# Altium schematic sheet SOC Led.SchDoc
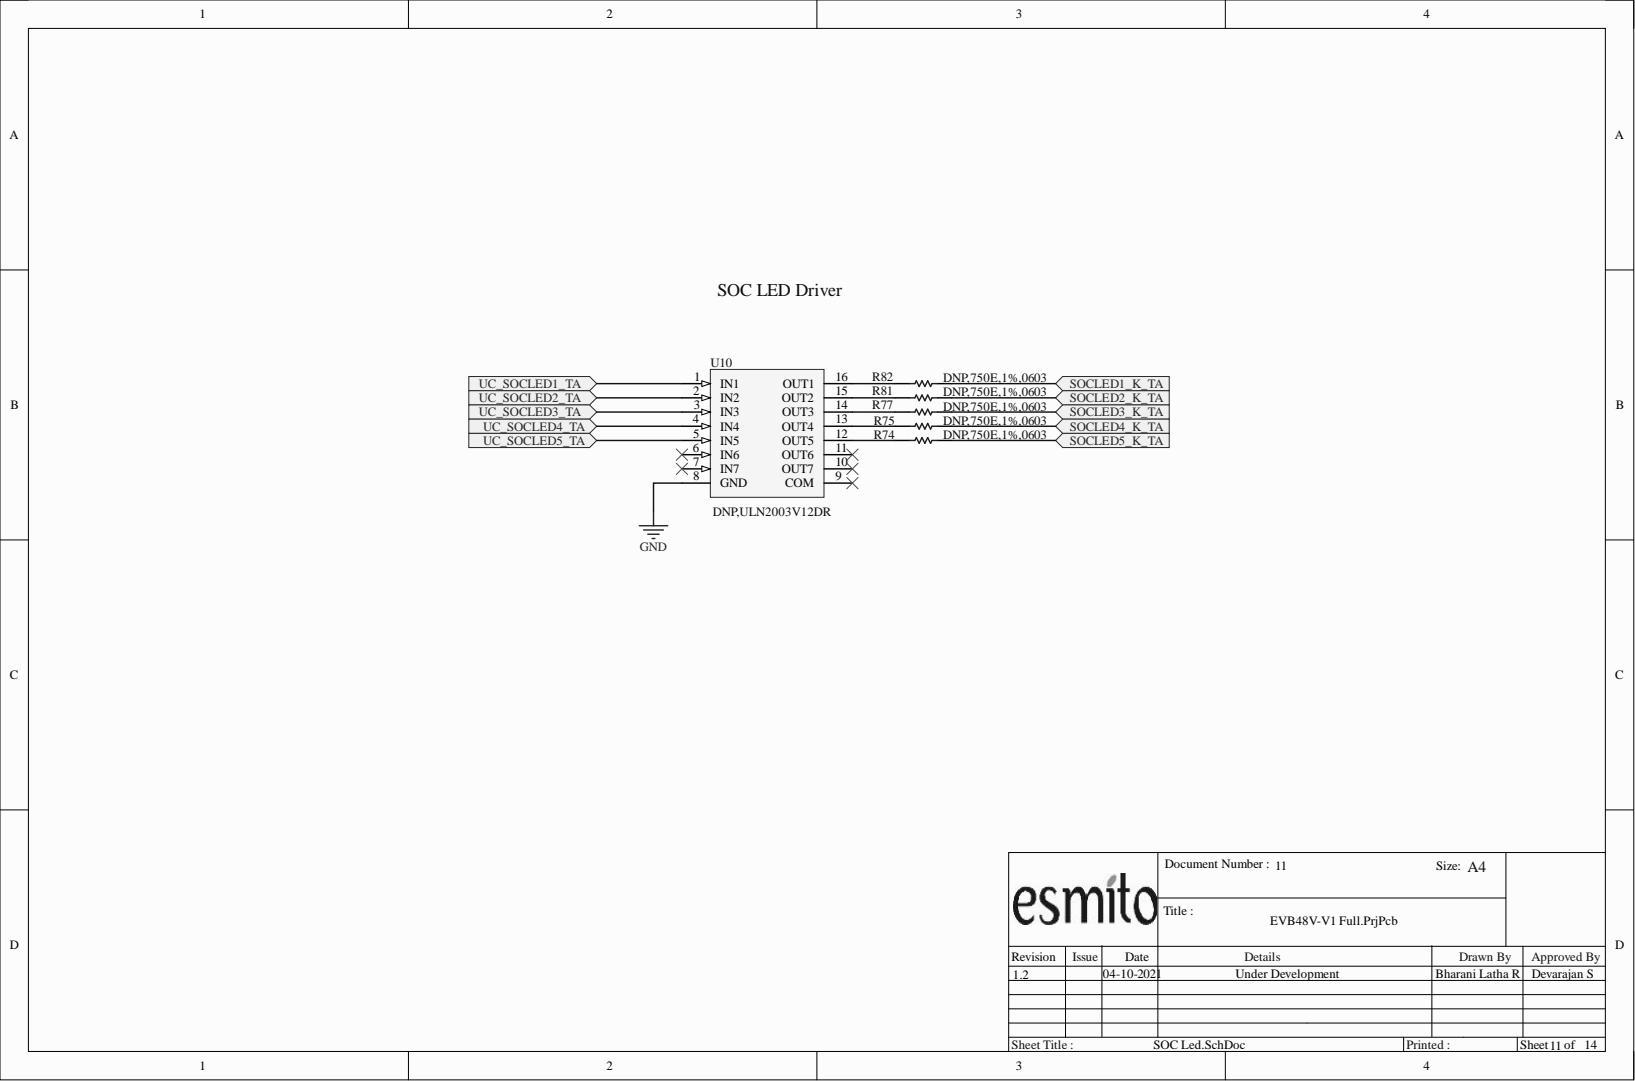
[diagram p0: SOC Led.SchDoc]

## Parts
R74  value DNP,750E,1%,0603  [0603_R_M]  (hidden comment: R_Semi)
R75  value DNP,750E,1%,0603  [0603_R_M]  (hidden comment: R_Semi)
R77  value DNP,750E,1%,0603  [0603_R_M]  (hidden comment: R_Semi)
R81  value DNP,750E,1%,0603  [0603_R_M]  (hidden comment: R_Semi)
R82  value DNP,750E,1%,0603  [0603_R_M]  (hidden comment: R_Semi)
U10  ULN2003  value DNP,ULN2003V12DR  [ULN2003V12S16-13]

## Ports
SOCLED1_K_TA, SOCLED2_K_TA, SOCLED3_K_TA, SOCLED4_K_TA, SOCLED5_K_TA, UC_SOCLED1_TA, UC_SOCLED2_TA, UC_SOCLED3_TA, UC_SOCLED4_TA, UC_SOCLED5_TA

## Nets
GND: U10.8(GND)
SOCLED1_K_TA: R82.2 ; port SOCLED1_K_TA
SOCLED2_K_TA: R81.2 ; port SOCLED2_K_TA
SOCLED3_K_TA: R77.2 ; port SOCLED3_K_TA
SOCLED4_K_TA: R75.2 ; port SOCLED4_K_TA
SOCLED5_K_TA: R74.2 ; port SOCLED5_K_TA
UC_SOCLED1_TA: U10.1(IN1) ; port UC_SOCLED1_TA
UC_SOCLED2_TA: U10.2(IN2) ; port UC_SOCLED2_TA
UC_SOCLED3_TA: U10.3(IN3) ; port UC_SOCLED3_TA
UC_SOCLED4_TA: U10.4(IN4) ; port UC_SOCLED4_TA
UC_SOCLED5_TA: U10.5(IN5) ; port UC_SOCLED5_TA
NetR74_1: R74.1 U10.12(OUT5)
NetR75_1: R75.1 U10.13(OUT4)
NetR77_1: R77.1 U10.14(OUT3)
NetR81_1: R81.1 U10.15(OUT2)
NetR82_1: R82.1 U10.16(OUT1)

Not connected: U10 6(IN6) 7(IN7) 9(COM) 10(OUT7) 11(OUT6)

## Library data of the parts
R74 R75 R77 R81 R82 = R_Semi: R, THK FLM, 750E, 1%, 100mW, 0603; Manufacturer: Yageo; Part Number: AC0603FR-07750RL; Component Kind: Standard; Signal Integrity: Res Semi; Simulation: RES; Library Name: Grinntech_Global_Library.IntLib
U10 = IC_ULN2003: Darlington Transistors Low Pwr Relay Driver, SO-16; Alternate Manufacturer: Diodes Incorporated; Manufacturer: Texas Instruments; Part Number: ULN2003V12DR; Alternate Part Number: ULN2003V12S16-13; Component Kind: Standard; Library Name: Grinntech_Global_SCH_Library.SchLib
